# Altium schematic sheet 1_Main.SchDoc
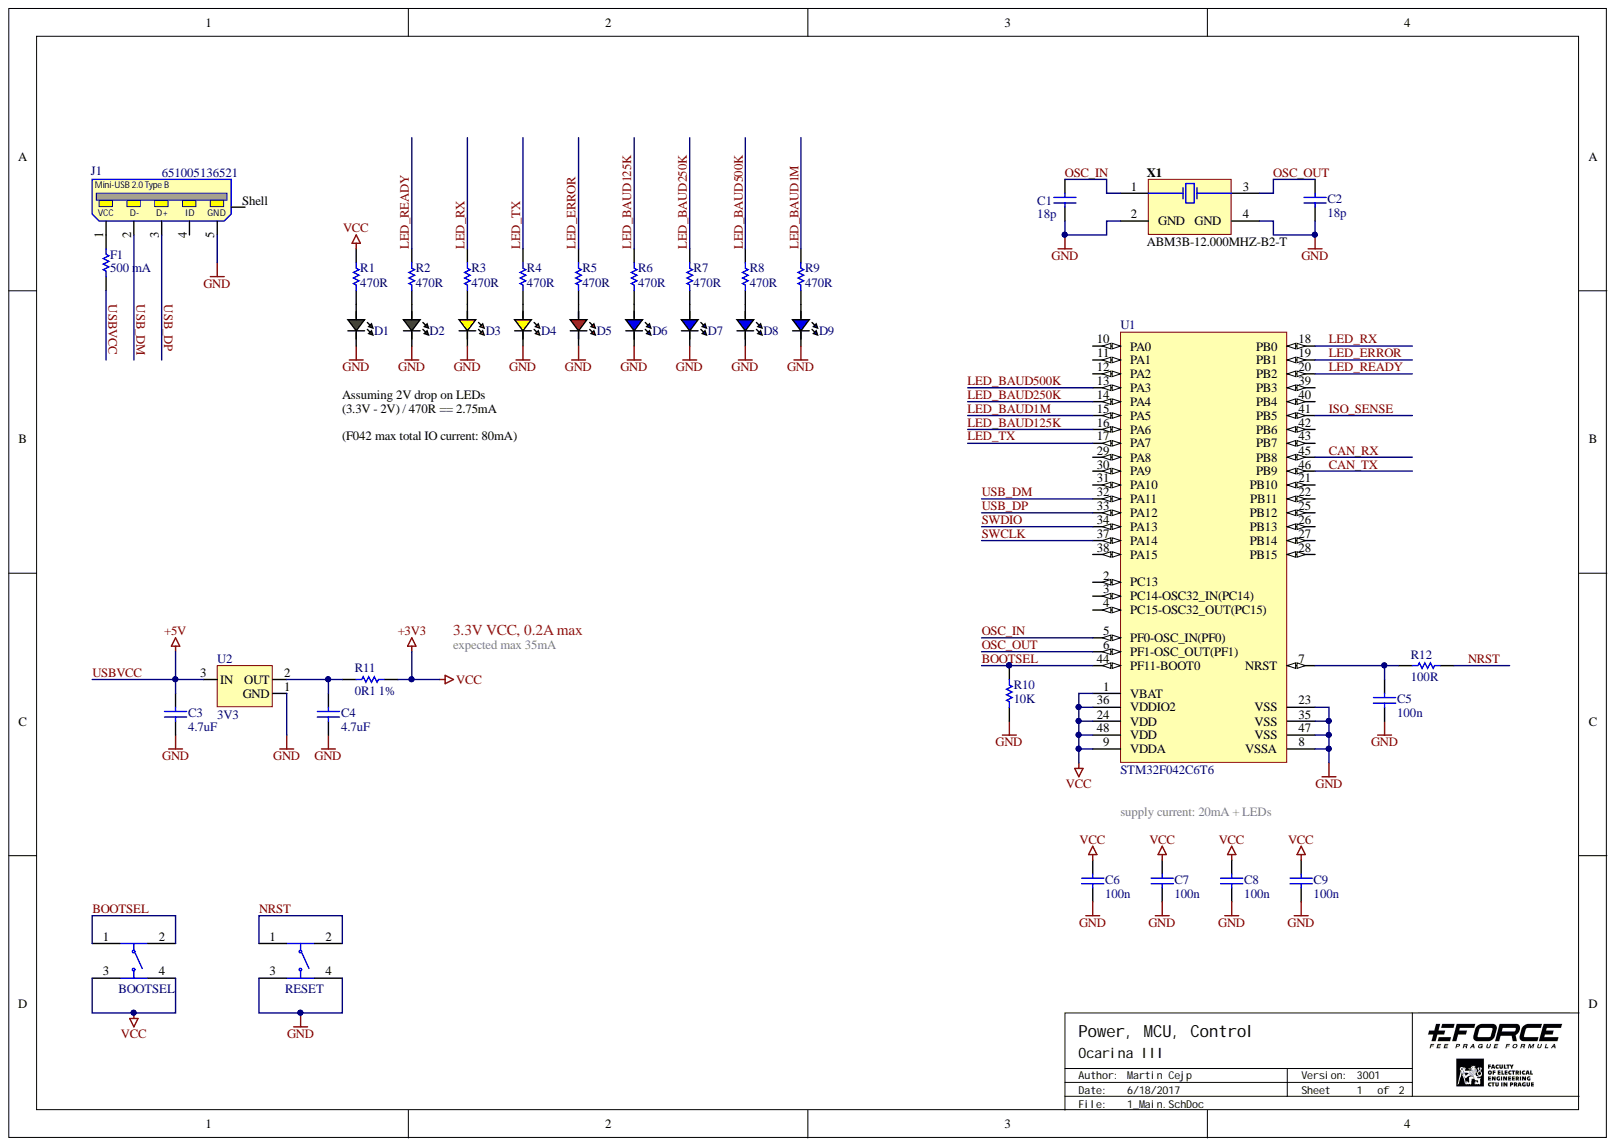
[diagram p0: 1_Main.SchDoc]

## Parts
BOOTSEL  430181038816  [BUTTON_4x3x2]  (value not drawn)
C1  18p  [CAPC0603(1608)100_M]
C2  18p  [CAPC0603(1608)100_M]
C3  4.7uF  [CAPC0805(2012)155_M]
C4  4.7uF  [CAPC0805(2012)155_M]
C5  100n  [CAPC0603(1608)100_M]
C6  100n  [CAPC0603(1608)100_M]
C7  100n  [CAPC0603(1608)100_M]
C8  100n  [CAPC0603(1608)100_M]
C9  100n  [CAPC0603(1608)100_M]
D1  green  [0805LEDG]  (value not drawn)
D2  green  [0805LEDG]  (value not drawn)
D3  yellow  [0805LEDYell]  (value not drawn)
D4  yellow  [0805LEDYell]  (value not drawn)
D5  red  [0805LEDR]  (value not drawn)
D6  blue  [0805LEDB]  (value not drawn)
D7  blue  [0805LEDB]  (value not drawn)
D8  blue  [0805LEDB]  (value not drawn)
D9  blue  [0805LEDB]  (value not drawn)
F1  500 mA  [RESC1812(4532)_L]
J1  651005136521  [MOLEX_548190519]
R1  470R  [RESC0603(1608)_N]
R2  470R  [RESC0603(1608)_N]
R3  470R  [RESC0603(1608)_N]
R4  470R  [RESC0603(1608)_N]
R5  470R  [RESC0603(1608)_N]
R6  470R  [RESC0603(1608)_N]
R7  470R  [RESC0603(1608)_N]
R8  470R  [RESC0603(1608)_N]
R9  470R  [RESC0603(1608)_N]
R10  10K  [RESC0603(1608)_N]
R11  0R1 1%  [RESC1206(3216)_L]
R12  100R  [RESC0603(1608)_L]
RESET  430181038816  [BUTTON_4x3x2]  (value not drawn)
U1  STM32F042C6T6  [STM-LQFP48_M]
U2  3V3  [SOT23m]
X1  ABM3B-12.000MHZ-B2-T  [ABRA-ABM3B-4_V]

## Nets
+3V3: BOOTSEL.3 BOOTSEL.4 C6.1 C7.1 C8.1 C9.1 R1.2 R11.2 U1.1(VBAT) U1.9(VDDA) U1.24(VDD) U1.36(VDDIO2) U1.48(VDD)
GND: C1.1 C2.1 C3.1 C4.1 C5.1 C6.2 C7.2 C8.2 C9.2 D1.K D2.K D3.K D4.K D5.K D6.K D7.K D8.K D9.K J1.5 R10.1 RESET.3 RESET.4 U1.8(VSSA) U1.23(VSS) U1.35(VSS) U1.47(VSS) U2.1 X1.2(GND) X1.4(GND)
BOOTSEL: BOOTSEL.1 BOOTSEL.2 R10.2 U1.44(PF11-BOOT0)
CAN_RX: U1.45(PB8)
CAN_TX: U1.46(PB9)
ISO_SENSE: U1.41(PB5)
LED_BAUD1M: R9.1 U1.15(PA5)
LED_BAUD125K: R6.1 U1.16(PA6)
LED_BAUD250K: R7.1 U1.14(PA4)
LED_BAUD500K: R8.1 U1.13(PA3)
LED_ERROR: R5.1 U1.19(PB1)
LED_READY: R2.2 U1.20(PB2)
LED_RX: R3.1 U1.18(PB0)
LED_TX: R4.1 U1.17(PA7)
NRST: R12.2 RESET.1 RESET.2
OSC_IN: C1.2 U1.5(PF0-OSC_IN(PF0)) X1.1
OSC_OUT: C2.2 U1.6(PF1-OSC_OUT(PF1)) X1.3
SWCLK: U1.37(PA14)
SWDIO: U1.34(PA13)
USB_DM: J1.2(D-) U1.32(PA11)
USB_DP: J1.3(D+) U1.33(PA12)
USBVCC: C3.2 F1.2 U2.3
NetC4_2: C4.2 R11.1 U2.2
NetC5_2: C5.2 R12.1 U1.7(NRST)
NetD1_A: D1.A R1.1
NetD2_A: D2.A R2.1
NetD3_A: D3.A R3.2
NetD4_A: D4.A R4.2
NetD5_A: D5.A R5.2
NetD6_A: D6.A R6.2
NetD7_A: D7.A R7.2
NetD8_A: D8.A R8.2
NetD9_A: D9.A R9.2
NetF1_1: F1.1 J1.1

Not connected: J1 4(ID) Shell; U1 2(PC13) 3(PC14-OSC32_IN(PC14)) 4(PC15-OSC32_OUT(PC15)) 10(PA0) 11(PA1) 12(PA2) 21(PB10) 22(PB11) 25(PB12) 26(PB13) 27(PB14) 28(PB15) 29(PA8) 30(PA9) 31(PA10) 38(PA15) 39(PB3) 40(PB4) 42(PB6) 43(PB7)

## Library data of the parts
BOOTSEL RESET = WS-TASV, 430181038816: WS-TASV 4.5x4.5 mm SMD; Manufacturer: Wurth Elektronik; Component Kind: Standard; ComponentLink1Description: Manufacturer; ComponentLink1URL: http://www.we-online.de; ComponentLink2Description: Datasheet; ComponentLink2URL: http://katalog.we-online.de/em/datasheet/430181038816.pdf; Created Date: Dec.2015; Library Name: WS-TASV_rev15a.intlib; partNo: 430181038816; Created by: Baojun Chen
C1 C2 = CMP-1035-00733-1: CAP 18pF 10V ±10% 0603 (1608 Metric) Thickness 1mm SMD; Case-EIA: 0603; Case-Metric: 1608; Tolerance: Â±10%; Component Kind: Standard; Library Name: Altium Content Vault; Max Thickness: 1 mm; Rated Voltage: 10 V; Technology: SMT; Value: 18pF
C3 C4 = CMP-1036-04892-1: CAP 4.7uF 16V ±20% 0805 (2012 Metric) Thickness 1.55mm SMD; Case-EIA: 0805; Case-Metric: 2012; Tolerance: Â±20%; Component Kind: Standard; Library Name: Altium Content Vault; Max Thickness: 1.55 mm; Rated Voltage: 16 V; Technology: SMT; Value: 4.7uF
C5-C9 = CMP-1035-02576-1: CAP 100nF 6.3V ±10% 0603 (1608 Metric) Thickness 1mm SMD; Case-EIA: 0603; Case-Metric: 1608; Tolerance: Â±10%; Component Kind: Standard; Library Name: Altium Content Vault; Max Thickness: 1 mm; Rated Voltage: 6.3 V; Technology: SMT; Value: 100nF
D1 D2 = LEDG_0805: Component Kind: Standard; Library Name: eForce_-_Optical and Light.SchLib
D3 D4 = LEDYell_0805: Component Kind: Standard; Library Name: eForce_-_Optical and Light.SchLib
D5 = LEDR_0805: Component Kind: Standard; Library Name: eForce_-_Optical and Light.SchLib
D6-D9 = LEDB_0805: Component Kind: Standard; Library Name: eForce_-_Optical and Light.SchLib
F1 = CMP-1016-00152-1: 1R02 0.75W 1% 1812 (4532 Metric)  SMD; Case-EIA: 1812; Case-Metric: 4532; Value: 1.02 Ohm; Component Kind: Standard; Library Name: Altium Content Vault; Power: 0.75 W; Technology: SMT; Tolerance: 1 %
J1 = 651005136521: WR-COM Mini USB 2.0 Type B Horizontal 5 Contacts; Component Kind: Standard; ComponentLink1Description: Manufacturer; ComponentLink1URL: http://www.we-online.de; ComponentLink2Description: Datasheet; ComponentLink2URL: http://katalog.we-online.de/em/datasheet/651005136521.pdf; Library Name: ALTIUM-WR-COM-rev16d.IntLib; PartNumber: 651005136521; Published: June 2016; Publisher: Wurth Elektronik
R1-R9 = CMP-1012-00066-1: 470R 0.1W 5% 0603 (1608 Metric)  SMD; Case-EIA: 0603; Case-Metric: 1608; Value: 470.00 Ohm; Component Kind: Standard; Library Name: Altium Content Vault; Power: 0.1 W; Technology: SMT; Tolerance: 5 %
R10 = CMP-1012-00098-1: 10K 0.1W 5% 0603 (1608 Metric)  SMD; Case-EIA: 0603; Case-Metric: 1608; Value: 10000.00 Ohm; Component Kind: Standard; Library Name: Altium Content Vault; Power: 0.1 W; Technology: SMT; Tolerance: 5 %
R11 = CMP-1014-00003-1: 0R1 0.25W 1% 1206 (3216 Metric)  SMD; Case-EIA: 1206; Case-Metric: 3216; Tolerance: 5 %; Value: 1.10 Ohm; Component Kind: Standard; Library Name: Altium Content Vault; Power: 0.25 W; Technology: SMT
R12 = CMP-1012-00050-1: 100R 0.1W 5% 0603 (1608 Metric)  SMD; Case-EIA: 0603; Case-Metric: 1608; Value: 100.00 Ohm; Component Kind: Standard; Library Name: Altium Content Vault; Power: 0.1 W; Technology: SMT; Tolerance: 5 %
U1 = CMP-0304-00103-1: ARM Cortex-M0 32-bit MCU, 32 KB Flash, 6 KB Internal RAM, 38 I/Os, 48-Pin LQFP, -40 to 85 degC, Tray; FLASH Size (Prog) (kB): 32; I/Os (High Current): 38; Internal RAM Size (kB): 6; Operating Frequency(F) (Processor speed) (MHz): 48; Supply Voltage(Vcc) min (V): 2; Component Kind: Standard; ComponentLink1Description: Manufacturer URL; ComponentLink1URL: http://www.st.com/; ComponentLink2Description: Datasheet; ComponentLink2URL: http://www.st.com/st-web-ui/static/active/en/resource/technical/document/datasheet/DM00105814.pdf; Core: ARM Cortex-M0; DatasheetVersion: Rev. 5; Library Name: Altium Content Vault; Mounting Technology: Surface Mount; Package Description: 48-Pin Low Profile Quad Flat Package, Body 7 x 7 mm, Pitch 0.5 mm; Package Reference: LQFP48; Packing: Tray
U2 = LM1117DT-3.3NOPB: 1.8V Voltage reference; Alias: MCP1700; Suplier: Farnell; Component Kind: Standard; Library Name: eForce_-_Power Supplies.SchLib
X1 = CMP-1688-00007-1: Ceramic SMD Crystal, 12 MHz, +/- 20 ppm, 70 Ohm, -20 to 70 degC, 4-Pin SMD, RoHS, Tape and Reel; Case\Package: TO-263-4; Dropout Voltage: 2 V; Max Input Voltage: 25 V; Max Ouput Current: 1.5 A; Min Input Voltage: 7 V; Nominal Output Voltage: 5 V; Outputs: 1; Component Kind: Standard; ComponentLink1Description: Manufacturer URL; ComponentLink1URL: http://www.abracon.com/; ComponentLink2Description: Datasheet; ComponentLink2URL: http://www.abracon.com/Resonators/abm3b.pdf; DatasheetVersion: Aug-15; Library Name: Altium Content Vault; Max Operating Temperature: 125 degC; Min Operating Temperature: 0 degC; Mount: Surface Mount; Output Current: 1.5 A; Output Voltage: 5 V; PackageDescription: 4-Pin Surface Mount Device, Body 5 x 3.2 mm; Package Quantity: 500; PackageReference: ABM3B; Packaging: Tape and Reel; Pins: 3; Radiation Hardening: No; REACH SVHC: No SVHC; RoHSCompliant: true; Weight: 0.050897 oz
